# Altium schematic sheet Sheet5.SchDoc(Sheet5)
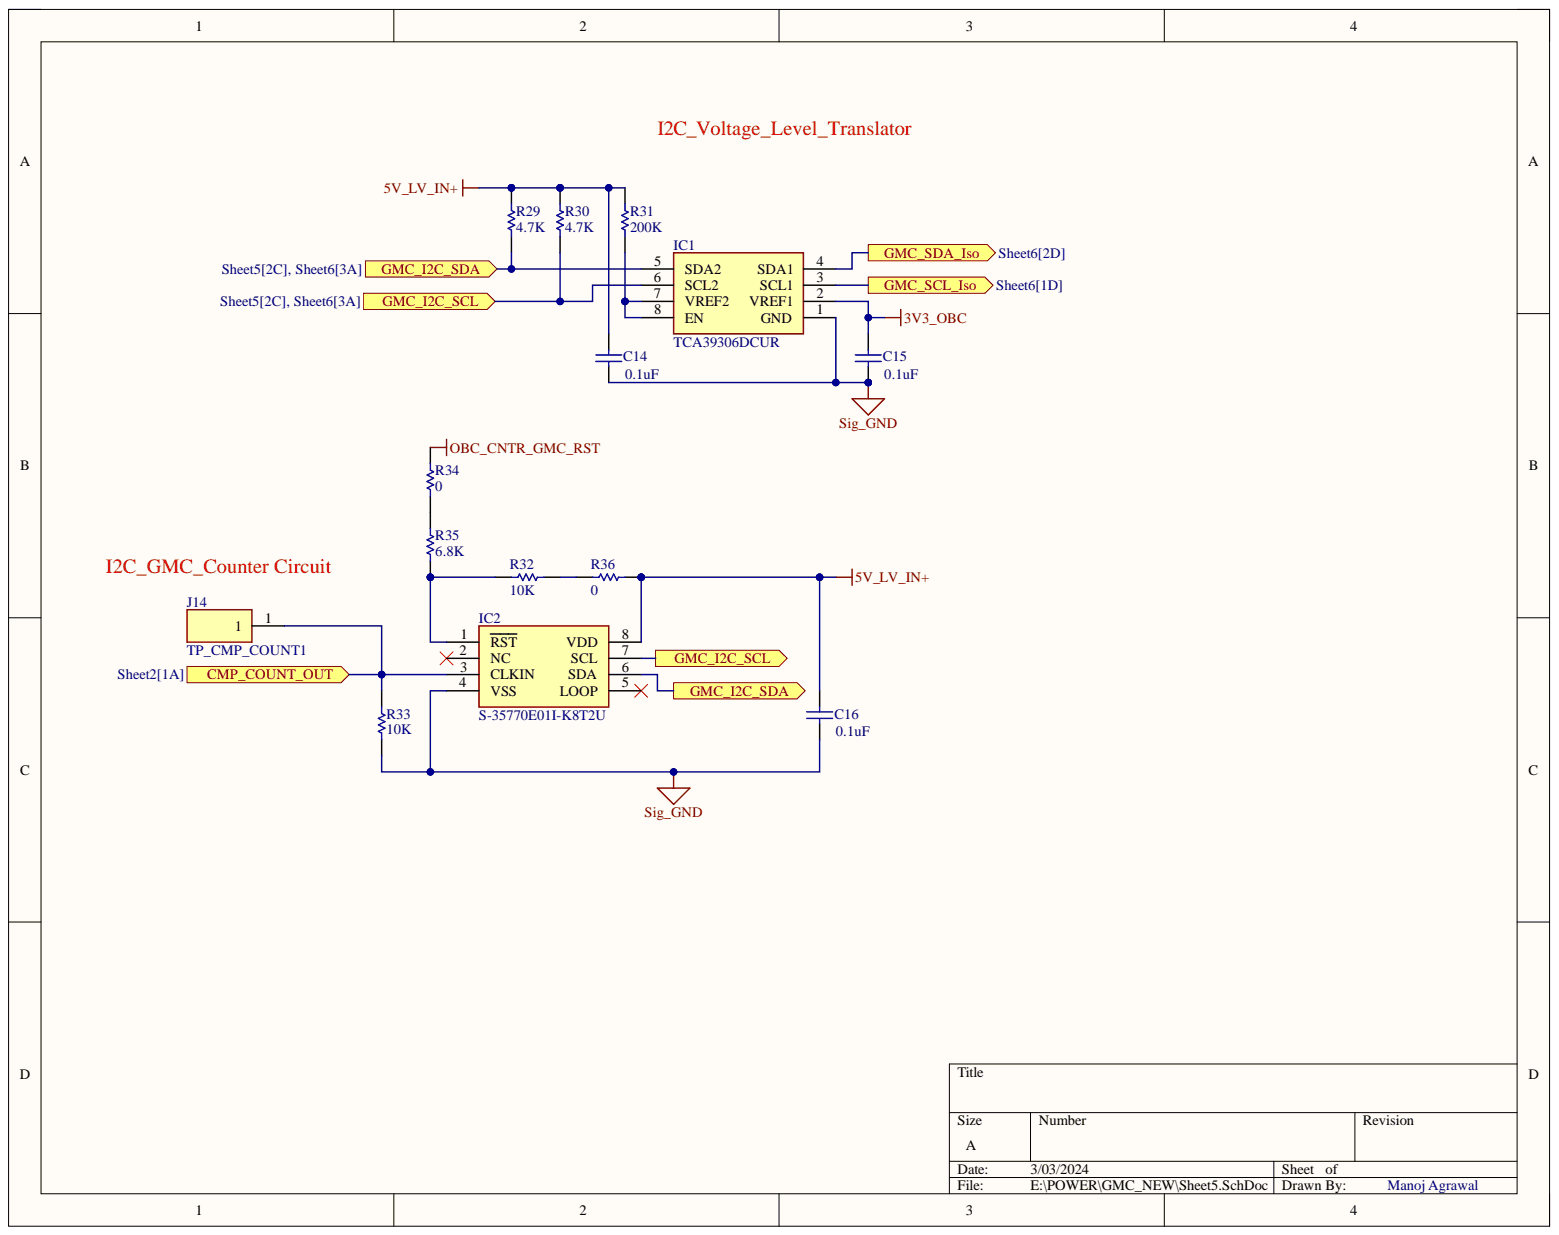
[diagram p0: Sheet5.SchDoc]

## Parts
C14  0.1uF  [CAPC2013X88X50LL20T25]
C15  0.1uF  [CAPC2013X88X50LL20T25]
C16  0.1uF  [CAPC2013X88X50LL20T25]
IC1  TCA39306DCUR  [SOP50P310X90-8N]
IC2  S-35770E01I-K8T2U  [SOP65P400X80-8N]
J14  TP_CMP_COUNT1  [HDRV1W64P0X254_1X1_254X248X838P]
R29  4.7K  [RESC2013X50X30LL20T20]
R30  4.7K  [RESC2013X50X30LL20T20]
R31  200K  [RESC2013X50X30LL20T20]
R32  10K  [RESC2013X50X30LL20T20]
R33  10K  [RESC2013X50X30LL20T20]
R34  0  [RESC2013X50X30LL20T20]
R35  6.8K  [RESC2013X50X30LL20T20]
R36  0  [RESC2013X50X30LL20T20]

## Ports
CMP_COUNT_OUT, GMC_I2C_SCL, GMC_I2C_SDA, GMC_SCL_Iso, GMC_SDA_Iso

## Nets
3V3_OBC: C15.2 IC1.2(VREF1)
5V_LV_IN+: C14.2 C16.2 IC2.8(VDD) R29.1 R30.1 R31.1 R36.2
OBC_CNTR_GMC_RST: R34.1
Sig_GND: C14.1 C15.1 C16.1 IC1.1(GND) IC2.4(VSS) R33.2
CMP_COUNT_OUT: IC2.3(CLKIN) J14.1 R33.1 ; port CMP_COUNT_OUT
GMC_I2C_SCL: IC1.6(SCL2) IC2.7(SCL) R30.2 ; port GMC_I2C_SCL
GMC_I2C_SDA: IC1.5(SDA2) IC2.6(SDA) R29.2 ; port GMC_I2C_SDA
GMC_SCL_Iso: IC1.3(SCL1) ; port GMC_SCL_Iso
GMC_SDA_Iso: IC1.4(SDA1) ; port GMC_SDA_Iso
NetIC1_7: IC1.7(VREF2) IC1.8(EN) R31.2
NetIC2_1: IC2.1(RST) R32.2 R35.2
NetR32_1: R32.1 R36.1
NetR34_2: R34.2 R35.1

Not connected: IC2 2(NC) 5(LOOP)

## Library data of the parts
C14-C16 = CMP-2007-00266-1: Manufacturer: Kemet; Part Number: C0805C103K5RACTU; Component Kind: Standard; Library Name: Altium Content Vault
IC1 = TCA39306DCUR: Integrated Circuit; Manufacturer_Name: Texas Instruments; Arrow Part Number: TCA39306DCUR; Arrow Price/Stock: https://www.arrow.com/en/products/tca39306dcur/texas-instruments?region=nac; Component Kind: Standard; Datasheet Link: https://www.ti.com/lit/ds/symlink/tca39306.pdf?ts=1631601354304&ref_url=https%253A%252F%252Fwww.google.com%252F; Height: 0.9mm; Manufacturer_Part_Number: TCA39306DCUR; Mouser Part Number: 595-TCA39306DCUR; Mouser Price/Stock: https://www.mouser.co.uk/ProductDetail/Texas-Instruments/TCA39306DCUR?qs=Wj%2FVkw3K%252BMBjyHfLT3ea4A%3D%3D
IC2 = S-35770E01I-K8T2U: Integrated Circuit; Manufacturer_Name: ABLIC Inc.; Component Kind: Standard; Datasheet Link: https://www.mouser.com/datasheet/2/360/S35770_I_E-1628617.pdf; Height: 0.8mm; Manufacturer_Part_Number: S-35770E01I-K8T2U; Mouser Part Number: 628-S35770E01I-K8T2U; Mouser Price/Stock: https://www.mouser.co.uk/ProductDetail/ABLIC/S-35770E01I-K8T2U?qs=vLWxofP3U2yvSXNQEw14rA%3D%3D
J14 = HTSW-101-07-L-S: Connector; Manufacturer_Name: SAMTEC; Arrow Part Number: HTSW-101-07-L-S; Arrow Price/Stock: https://www.arrow.com/en/products/htsw-101-07-l-s/samtec; Component Kind: Standard; Datasheet Link: https://www.arrow.com/en/products/htsw-101-07-l-s/samtec; Height: 8.38mm; Library Name: OBC3.0.SchLib; Manufacturer_Part_Number: HTSW-101-07-L-S; Mouser Part Number: 200-HTSW10107LS; Mouser Price/Stock: https://www.mouser.co.uk/ProductDetail/Samtec/HTSW-101-07-L-S?qs=0lQeLiL1qybicCfG80ZrYQ%3D%3D
R29-R36 = CMP-2001-04896-1: Manufacturer: Vishay Dale; Part Number: CRCW08050000Z0EA; Component Kind: Standard; Library Name: Altium Content Vault
